# Altium schematic sheet STM32.SchDoc(STM32)
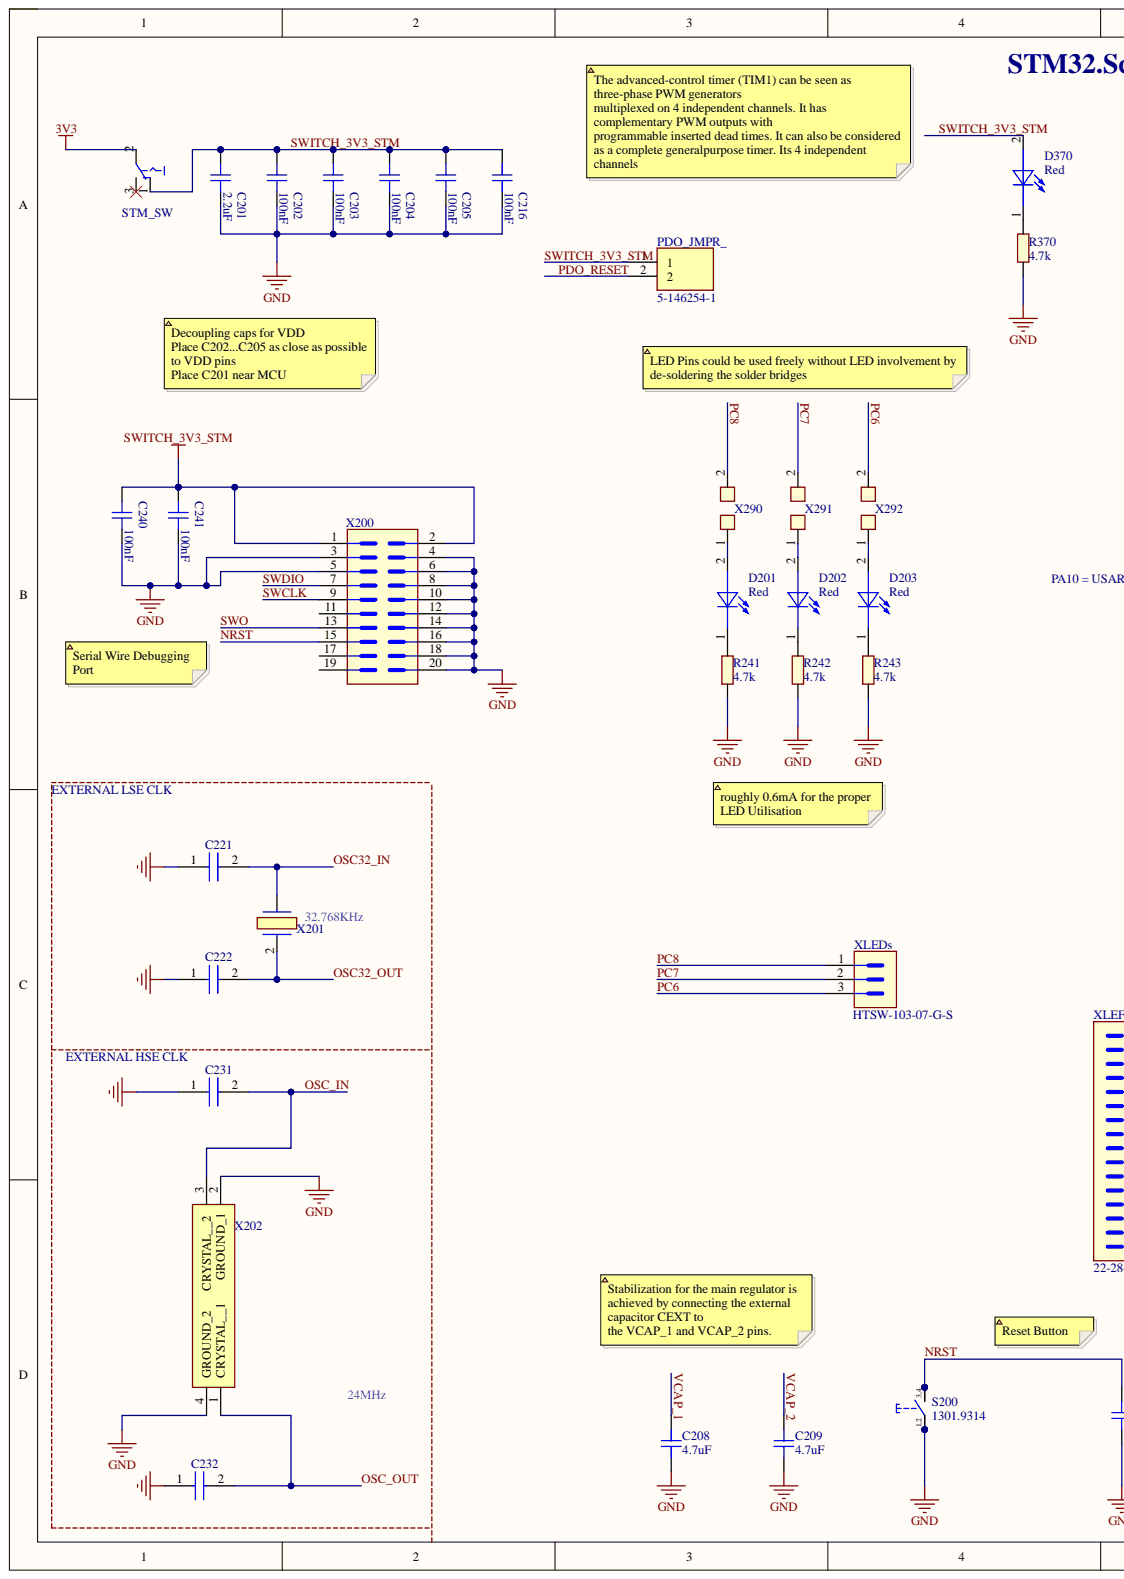
[diagram p0: STM32.SchDoc, left]
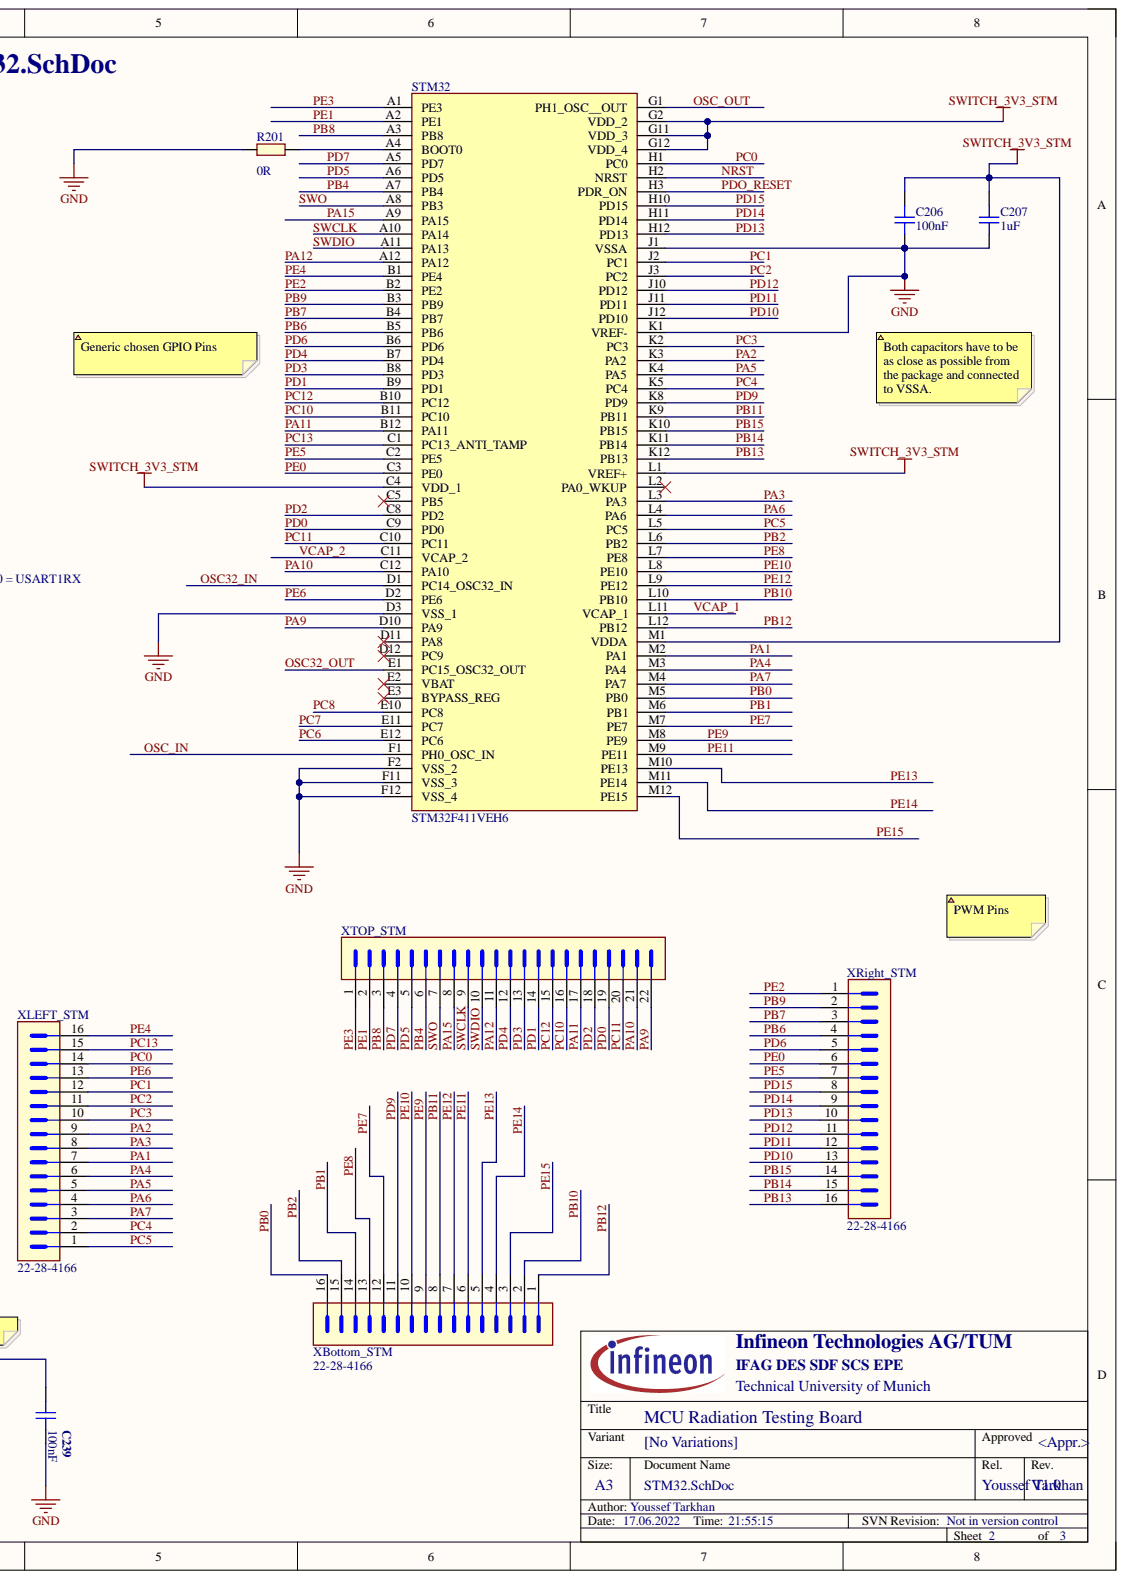
[diagram p1: STM32.SchDoc, right]

## Parts
C201  2.2uF  [CAPC1608X90N-3]
C202  100nF  [CAPC1608X90N-2]
C203  100nF  [CAPC1608X90N-2]
C204  100nF  [CAPC1608X90N-2]
C205  100nF  [CAPC1608X90N-2]
C206  100nF  [CAPC1608X90N-2]
C207  1uF  [CAPC1608X50N]
C208  4.7uF  [CAPC1608X95N]
C209  4.7uF  [CAPC1608X95N]
C216  100nF  [CAPC1608X90N-2]
C221  CC0402CRNPO9BN5R1  [CAPC1005X55N]  (value not drawn)
C222  CC0402CRNPO9BN5R1  [CAPC1005X55N]  (value not drawn)
C231  CC0603CRNPO9BN6R8  [CAPC1608X90N]  (value not drawn)
C232  CC0603CRNPO9BN6R8  [CAPC1608X90N]  (value not drawn)
C239  100nF  [CAPC1608X90N-2]
C240  100nF  [CAPC1608X90N-2]
C241  100nF  [CAPC1608X90N-2]
D201  Red  [LEDSC160X80X80-2N-1]
D202  Red  [LEDSC160X80X80-2N-1]
D203  Red  [LEDSC160X80X80-2N-1]
D370  Red  [LEDSC160X80X80-2N-1]
PDO_JMPR_  5-146254-1  [HDRV2W68P254_2X1_213X488X1045P]
R201  0R  [RESC1608X55N-1]
R241  4.7k  [RESC1609X55N]
R242  4.7k  [RESC1609X55N]
R243  4.7k  [RESC1609X55N]
R370  4.7k  [RESC1609X55N]
S200  1301.9314  [SW-SMD-1301.9314]
STM32  STM32F411VEH6  [BGA100C50P12X12_700X700X60]
STM_SW  CL-SB-12A-01T  [SW-SMD-CL-SB-12A-01T]  (value not drawn)
X200  827-22-020-10-003101  [CON-M-THT-827-22-020-10-003101]  (value not drawn)
X201  NX3215SA-32.768KHZ-EXS00A-MU00525  [NX3215SA32768KHZEXS00AMU00525]  (value not drawn)
X202  NX2016SA-24MHZ-EXS00A-CS10820  [NX2016SA24MHZEXS00ACS10820]  (value not drawn)
X290  Solder Jumper 2 Pins  [SOLDER_BRIDGE_0.7X1.299PAD]  (value not drawn)
X291  Solder Jumper 2 Pins  [SOLDER_BRIDGE_0.7X1.299PAD]  (value not drawn)
X292  Solder Jumper 2 Pins  [SOLDER_BRIDGE_0.7X1.299PAD]  (value not drawn)
XBottom_STM  22-28-4166  [HDRV16W66P254_1X16_4064X249X838B]
XLEDs  HTSW-103-07-G-S  [CON-THT-2.54-3-1-8.38]
XLEFT_STM  22-28-4166  [HDRV16W66P254_1X16_4064X249X838B]
XRight_STM  22-28-4166  [HDRV16W66P254_1X16_4064X249X838B]
XTOP_STM  TSW-122-07-L-S  [CON-M-THT-TSW-122-07-L-S]  (value not drawn)

## Nets
3V3: STM_SW.2
GND: C201.1 C202.1 C203.1 C204.1 C205.1 C206.2 C207.1 C208.1 C209.1 C216.1 C221.1 C222.1 C231.1 C232.1 C239.1 C240.1 C241.1 R201.1 R241.1 R242.1 R243.1 R370.1 S200.1 S200.2 STM32.D3(VSS_1) STM32.F2(VSS_2) STM32.F11(VSS_3) STM32.F12(VSS_4) STM32.J1(VSSA) STM32.K1(VREF-) X200.3 X200.4 X200.5 X200.6 X200.8 X200.10 X200.12 X200.14 X200.16 X200.18 X200.20 X202.2(GROUND_1) X202.4(GROUND_2)
NRST: C239.2 S200.3 S200.4 STM32.H2(NRST) X200.15
OSC32_IN: C221.2 STM32.D1(PC14_OSC32_IN) X201.
OSC32_OUT: C222.2 STM32.E1(PC15_OSC32_OUT) X201.2
OSC_IN: C231.2 STM32.F1(PH0_OSC_IN) X202.3(CRYSTAL__2)
OSC_OUT: C232.2 STM32.G1(PH1_OSC__OUT) X202.1(CRYSTAL__1)
PA1: STM32.M2(PA1) XLEFT_STM.7
PA2: STM32.K3(PA2) XLEFT_STM.9
PA3: STM32.L3(PA3) XLEFT_STM.8
PA4: STM32.M3(PA4) XLEFT_STM.6
PA5: STM32.K4(PA5) XLEFT_STM.5
PA6: STM32.L4(PA6) XLEFT_STM.4
PA7: STM32.M4(PA7) XLEFT_STM.3
PA9: STM32.D10(PA9) XTOP_STM.22
PA10: STM32.C12(PA10) XTOP_STM.21
PA11: STM32.B12(PA11) XTOP_STM.17
PA12: STM32.A12(PA12) XTOP_STM.11
PA15: STM32.A9(PA15) XTOP_STM.8
PB0: STM32.M5(PB0) XBottom_STM.16
PB1: STM32.M6(PB1) XBottom_STM.14
PB2: STM32.L6(PB2) XBottom_STM.15
PB4: STM32.A7(PB4) XTOP_STM.6
PB6: STM32.B5(PB6) XRight_STM.4
PB7: STM32.B4(PB7) XRight_STM.3
PB8: STM32.A3(PB8) XTOP_STM.3
PB9: STM32.B3(PB9) XRight_STM.2
PB10: STM32.L10(PB10) XBottom_STM.2
PB11: STM32.K9(PB11) XBottom_STM.8
PB12: STM32.L12(PB12) XBottom_STM.1
PB13: STM32.K12(PB13) XRight_STM.16
PB14: STM32.K11(PB14) XRight_STM.15
PB15: STM32.K10(PB15) XRight_STM.14
PC0: STM32.H1(PC0) XLEFT_STM.14
PC1: STM32.J2(PC1) XLEFT_STM.12
PC2: STM32.J3(PC2) XLEFT_STM.11
PC3: STM32.K2(PC3) XLEFT_STM.10
PC4: STM32.K5(PC4) XLEFT_STM.2
PC5: STM32.L5(PC5) XLEFT_STM.1
PC6: STM32.E12(PC6) X292.2 XLEDs.3
PC7: STM32.E11(PC7) X291.2 XLEDs.2
PC8: STM32.E10(PC8) X290.2 XLEDs.1
PC10: STM32.B11(PC10) XTOP_STM.16
PC11: STM32.C10(PC11) XTOP_STM.20
PC12: STM32.B10(PC12) XTOP_STM.15
PC13: STM32.C1(PC13_ANTI_TAMP) XLEFT_STM.15
PD0: STM32.C9(PD0) XTOP_STM.19
PD1: STM32.B9(PD1) XTOP_STM.14
PD2: STM32.C8(PD2) XTOP_STM.18
PD3: STM32.B8(PD3) XTOP_STM.13
PD4: STM32.B7(PD4) XTOP_STM.12
PD5: STM32.A6(PD5) XTOP_STM.5
PD6: STM32.B6(PD6) XRight_STM.5
PD7: STM32.A5(PD7) XTOP_STM.4
PD9: STM32.K8(PD9) XBottom_STM.11
PD10: STM32.J12(PD10) XRight_STM.13
PD11: STM32.J11(PD11) XRight_STM.12
PD12: STM32.J10(PD12) XRight_STM.11
PD13: STM32.H12(PD13) XRight_STM.10
PD14: STM32.H11(PD14) XRight_STM.9
PD15: STM32.H10(PD15) XRight_STM.8
PDO_RESET: PDO_JMPR_.2 STM32.H3(PDR_ON)
PE0: STM32.C3(PE0) XRight_STM.6
PE1: STM32.A2(PE1) XTOP_STM.2
PE2: STM32.B2(PE2) XRight_STM.1
PE3: STM32.A1(PE3) XTOP_STM.1
PE4: STM32.B1(PE4) XLEFT_STM.16
PE5: STM32.C2(PE5) XRight_STM.7
PE6: STM32.D2(PE6) XLEFT_STM.13
PE7: STM32.M7(PE7) XBottom_STM.12
PE8: STM32.L7(PE8) XBottom_STM.13
PE9: STM32.M8(PE9) XBottom_STM.9
PE10: STM32.L8(PE10) XBottom_STM.10
PE11: STM32.M9(PE11) XBottom_STM.6
PE12: STM32.L9(PE12) XBottom_STM.7
PE13: STM32.M10(PE13) XBottom_STM.5
PE14: STM32.M11(PE14) XBottom_STM.4
PE15: STM32.M12(PE15) XBottom_STM.3
SWCLK: STM32.A10(PA14) X200.9 XTOP_STM.9
SWDIO: STM32.A11(PA13) X200.7 XTOP_STM.10
SWITCH_3V3_STM: C201.2 C202.2 C203.2 C204.2 C205.2 C206.1 C207.2 C216.2 C240.2 C241.2 D370.2 PDO_JMPR_.1 STM32.C4(VDD_1) STM32.G2(VDD_2) STM32.G11(VDD_3) STM32.G12(VDD_4) STM32.L1(VREF+) STM32.M1(VDDA) STM_SW.1 X200.1 X200.2
SWO: STM32.A8(PB3) X200.13 XTOP_STM.7
VCAP_1: C208.2 STM32.L11(VCAP_1)
VCAP_2: C209.2 STM32.C11(VCAP_2)
NetD201_1: D201.1 R241.2
NetD201_2: D201.2 X290.1
NetD202_1: D202.1 R242.2
NetD202_2: D202.2 X291.1
NetD203_1: D203.1 R243.2
NetD203_2: D203.2 X292.1
NetD370_1: D370.1 R370.2
NetR201_2: R201.2 STM32.A4(BOOT0)
NetSTM32_E2: STM32.E2(VBAT)
NetSTM32_E3: STM32.E3(BYPASS_REG)
NetSTM32_L2: STM32.L2(PA0_WKUP)
NetSTM_SW_3: STM_SW.3
NetX200_11: X200.11
NetX200_17: X200.17
NetX200_19: X200.19

Not connected: STM32 C5(PB5) D11(PA8) D12(PC9)

## Library data of the parts
C201 = 310650: CAP / CERA / 2.2uF / 25V / 10% / X5R (EIA) / -55°C to 85°C / 0603(1608) / SMD / -; Manufacturer: MuRata; Manufacturer Order Number: GRM188R61E225KA12D; Alternate View: Yes; Automotive Qualified: No; Combined Parameters: TC:X5R (EIA);Tol.:-10%/+10%;Vdc:25V; ComponentStatus: Released; Database Table Name: TPartsAll; Legacy DeviceID: CAP01010924; Package Imperial: 0603; Package Metric: 1608; PLMStatus: Active; QuickPick: 2.2uF / 25V / 10% / X5R (EIA) / 0603 (1608M); Style: Single; Symbol Type: Capacitor; Single; Standard; 2 Pins; Type: Standard; Version Number: V01; Article Number: 310650; Component Kind: Standard; ComponentLink1Description: Vendor WebSite; ComponentLink1URL: http://www.murata.com/; ComponentLink2Description: Datasheet; ComponentLink2URL: http://webnetprod.muc.infineon.com/PCBPortal_Prod/WorkFlows/AltiumComponent/StandardDocumentation?Versionid=310651; Legacy PartNumber: CAP01010924; Library Name: PCBLibraryData.SVNDbLib; Multiple Parts: No; Package Description: Capacitor,non-polarized,Chip;1.60mm L X 0.80mm W X 0.90mm H; PackageReference: 0603 (1608M); Part Type: Capacitor; ROHS Compliant: Y; Second Source allowed: Yes; Supplier 1: Farnell; Supplier 2: DigiKey; Supplier 3: Mouser; Supplier Part Number 1: 1845734RL; Supplier Part Number 2: 490-10731-2-ND; Supplier Part Number 3: 81-GRM188R61E225KA2D; Technology: Ceramic; Temperature max: 85; Temperature min: -55; Temperature Range: Military; Tolerance Neg: 10%; Tolerance Pos: 10%; Value: 2.2uF; Voltage DC (max): 25V
C202-C206 C216 C239-C241 = 479045: CAP / CERA / 100nF / 50V / 10% / X7R (EIA) / -55°C to 125°C / 0603 / SMD / -; Manufacturer: AVX; Manufacturer Order Number: 06035C104KAT2A; Alternate View: Yes; Automotive Qualified: No; Combined Parameters: TC:X7R (EIA);Tol.:-10%/+10%;Vdc:50V; ComponentStatus: Released; Database Table Name: TPartsAll; PLMStatus: Active; QuickPick: 100nF / 50V / 10% / X7R (EIA) / 0603; Style: Single; Symbol Type: Capacitor; Single; Standard; 2 Pins; Type: Standard; Version Number: V01; Application: Standard; Article Number: 479045; Component Kind: Standard; ComponentLink1Description: Vendor WebSite; ComponentLink1URL: http://www.avx.com; ComponentLink2Description: Datasheet; ComponentLink2URL: http://webnetprod.muc.infineon.com/PCBPortal_Prod/WorkFlows/AltiumComponent/StandardDocumentation?Versionid=479046; Library Name: PCBLibraryData.SVNDbLib; Multiple Parts: No; Package Description: Capacitor,non-polarized,Chip;1.60mm L X 0.81mm W X 0.90mm H; PackageReference: 0603; Part Type: Capacitor; ROHS Compliant: Y; Second Source allowed: Yes; Supplier 1: Farnell; Supplier 2: DigiKey; Supplier 3: Mouser; Supplier Part Number 1: 2280883; Supplier Part Number 2: 478-5052-2-ND; Supplier Part Number 3: 581-06035C104KAT2A; Technology: Ceramic; Temperature max: 125; Temperature min: -55; Temperature Range: Military; Tolerance Neg: 10%; Tolerance Pos: 10%; Value: 100nF; Voltage DC (max): 50V
C207 = 267094: CAP / CERA / 1uF / 6.3V / 10% / X5R (EIA) / -55°C to 85°C / 0603(1608) / SMD / -; Manufacturer: MuRata; Manufacturer Order Number: GRM185R60J105KE26; Alternate View: Yes; Automotive Qualified: No; Combined Parameters: TC:X5R (EIA);Tol.:-10%/+10%;Vdc:6.3V; ComponentStatus: Released; Database Table Name: TPartsAll; Legacy DeviceID: CAP01002425; Package Imperial: 0603; Package Metric: 1608; PLMStatus: Not Recommended For New Designs; QuickPick: 1uF / 6.3V / 10% / X5R (EIA) / 0603 (1608); Style: Single; Symbol Type: Capacitor; Single; Standard; 2 Pins; Type: Standard; Version Number: V01; Application: Standard; Article Number: 267094; Component Kind: Standard; ComponentLink1Description: Vendor WebSite; ComponentLink1URL: http://www.murata.com/; ComponentLink2Description: Datasheet; ComponentLink2URL: http://webnetprod.muc.infineon.com/PCBPortal_Prod/WorkFlows/AltiumComponent/StandardDocumentation?Versionid=267095; Legacy PartNumber: CAP01002425; Library Name: PCBLibraryData.SVNDbLib; Multiple Parts: No; Package Description: Capacitor,non-polarized,Chip;1.60mm L X 0.80mm W X 0.50mm H; PackageReference: 0603 (1608); Part Type: Capacitor; ROHS Compliant: Y; Second Source allowed: Yes; Supplier 1: Farnell; Supplier 2: DigiKey; Supplier 3: Mouser; Technology: Ceramic; Temperature max: 85; Temperature min: -55; Temperature Range: Military; Tolerance Neg: 10%; Tolerance Pos: 10%; Value: 1uF; Voltage DC (max): 6.3V
C208 C209 = 308695: CAP / CERA / 4.7uF / 6.3V / 10% / X7R (EIA) / -55°C to 125°C / 0603(1608) / SMD / -; Manufacturer: Samsung; Manufacturer Order Number: CL10B475KQ8NQNC; Alternate View: Yes; Automotive Qualified: No; Combined Parameters: TC:X7R (EIA);Tol.:-10%/+10%;Vdc:6.3V; ComponentStatus: Released; Database Table Name: TPartsAll; Legacy DeviceID: CAP01010671; Package Imperial: 0603; Package Metric: 1608; PLMStatus: Active; QuickPick: 4.7uF / 6.3V / 10% / X7R (EIA) / 0603 (1608); Style: Single; Symbol Type: Capacitor; Single; Standard; 2 Pins; Type: Standard; Version Number: V01; Article Number: 308695; Component Kind: Standard; ComponentLink1Description: Vendor WebSite; ComponentLink1URL: http://www.samsungsem.co.kr/; ComponentLink2Description: Datasheet; ComponentLink2URL: http://webnetprod.muc.infineon.com/PCBPortal_Prod/WorkFlows/AltiumComponent/StandardDocumentation?Versionid=308696; Legacy PartNumber: CAP01010671; Library Name: PCBLibraryData.SVNDbLib; Multiple Parts: No; Package Description: Capacitor,non-polarized,Chip;1.60mm L X 0.80mm W X 0.95mm H; PackageReference: 0603 (1608); Part Type: Capacitor; ROHS Compliant: Y; Second Source allowed: Yes; Supplier 1: Farnell; Supplier 2: DigiKey; Supplier 3: Mouser; Supplier Part Number 2: 1276-2087-2-ND; Technology: Ceramic; Temperature max: 125; Temperature min: -55; Temperature Range: Military; Tolerance Neg: 10%; Tolerance Pos: 10%; Value: 4.7uF; Voltage DC (max): 6.3V
C221 C222 = CC0402CRNPO9BN5R1: Capacitor; Manufacturer_Name: KEMET; Component Kind: Standard; Datasheet Link: https://www.yageo.com/upload/media/product/productsearch/datasheet/mlcc/UPY-GP_NP0_16V-to-50V_18.pdf; Height: 0.55mm; Manufacturer_Part_Number: CC0402CRNPO9BN5R1
C231 C232 = CC0603CRNPO9BN6R8: Capacitor  6.8pF; Manufacturer_Name: KEMET; Component Kind: Standard; Height: 0.9mm; Manufacturer_Part_Number: CC0603CRNPO9BN6R8
D201-D203 D370 = 625459: WL-SMCW SMT Mono-color Chip LED Waterclear, Red,630nm; Manufacturer: Wurth Elektronik; Manufacturer Order Number: 150060RS75000; Automotive Qualified: No; Combined Parameters: Vf:2.4V;If:30mA;Ur:5V;Ir:10uA;Pmax:72mW; ComponentStatus: Released; Database Table Name: TPartsAll; PLMStatus: Active; QuickPick: LED / 1608 (0603); Second Source allowed: Yes; Style: Single; Symbol Type: Standard LED Symbol, 1 Cathode, 2 Anode; Version Number: V01; Voltage DC (max): na; Application: Standard; Article Number: 625459; Component Kind: Standard; ComponentLink1Description: Vendor WebSite; ComponentLink1URL: http://www.we-online.com; ComponentLink2Description: Datasheet; ComponentLink2URL: http://webnetprod.muc.infineon.com/PCBPortal_Prod/WorkFlows/AltiumComponent/StandardDocumentation?Versionid=625460; Library Name: PCBLibraryData.SVNDbLib; Package Description: LED,Side Concave; 2 pin,1.60mm W X 0.80mm L X 0.80mm H; PackageReference: 1608 (0603); Part Type: Diode; ROHS Compliant: Y; Supplier 1: Farnell; Supplier 2: DigiKey; Supplier 3: Mouser; Supplier Part Number 1: 2322071; Supplier Part Number 2: 732-4978-1-ND; Supplier Part Number 3: 710-150060RS75000; Temperature max: 85; Temperature min: -40; Temperature Range: Industrial; Type: LED; Value: Red
PDO_JMPR_ = 5-146254-1: Connector; Manufacturer_Name: TE Connectivity; Component Kind: Standard; Datasheet Link: https://www.te.com/commerce/DocumentDelivery/DDEController?Action=srchrtrv&DocNm=146254&DocType=Customer+Drawing&DocLang=English; Height: 10.45mm; Manufacturer_Part_Number: 5-146254-1
R201 = 328011: RES / STD / 0R / 100mW / 0R / 0ppm/K / -55°C to 155°C / 0603(1608) / SMD / -; Manufacturer: Yageo; Manufacturer Order Number: RC0603JR-070RL; Alternate View: Yes; Automotive Qualified: No; Combined Parameters: TCR:0ppm/K;Tol.:-0R/+0R;Vdc:75V;Idc:1A;P:100mW; ComponentStatus: Released; Database Table Name: TPartsAll; Legacy DeviceID: RES00000126; Package Imperial: 0603; Package Metric: 1608; PLMStatus: Active; QuickPick: 0R / 0R / 0.1 / 0603 (1608); Style: Single; Symbol Type: Resistor; Single; Standard; 2 Pins; Version Number: V01; Voltage DC (max): 75V; Article Number: 328011; Component Kind: Standard; ComponentLink1Description: Vendor WebSite; ComponentLink1URL: http://www.yageo.com/; ComponentLink2Description: Datasheet; ComponentLink2URL: http://webnetprod.muc.infineon.com/PCBPortal_Prod/WorkFlows/AltiumComponent/StandardDocumentation?Versionid=328012; Legacy PartNumber: RES00000126; Library Name: PCBLibraryData.SVNDbLib; Multiple Parts: No; PackageReference: 0603 (1608); Part Type: Resistor; ROHS Compliant: Y; Second Source allowed: Yes; Supplier 1: Farnell; Supplier 2: DigiKey; Supplier 3: Mouser; Supplier Part Number 1: 9233130; Supplier Part Number 2: 311-0.0GRTR-ND; Supplier Part Number 3: 603-RC0603JR-070RL; Technology: Thick Film; Temperature max: 155; Temperature min: -55; Temperature Range: Military; Tolerance Neg: 0R; Tolerance Pos: 0R; Type: Standard; Value: 0R
R241-R243 R370 = 699381: RES / STD / 4.7k / 100mW / 1% / 100ppm/K / -55°C to 155°C / 1.60mm L X 0.85mm W X 0.55mm H / - / -; Manufacturer: Panasonic; Manufacturer Order Number: ERJ3EKF4701V; Multiple Parts: No; Alternate View: Yes; Automotive Qualified: AEC Q200; Combined Parameters: TCR:100ppm/K;Tol.:-1%/+1%;Vdc:-;Idc:-;P:100mW; ComponentStatus: Released; Database Table Name: TPartsAll; PLMStatus: Active; QuickPick: 4.7k / 1% / 0.1 / ERJ3EKF4701V; Style: Single; Symbol Type: Resistor; Single; Standard; 2 Pins; Version Number: V00; Application: Standard; Article Number: 699381; Component Kind: Standard; ComponentLink1Description: Vendor WebSite; ComponentLink1URL: http://panasonic.net/sanyo/index.html; ComponentLink2Description: Datasheet; ComponentLink2URL: http://webnetprod.muc.infineon.com/PCBPortal_Prod/WorkFlows/AltiumComponent/StandardDocumentation?Versionid=699382; Library Name: PCBLibraryData.SVNDbLib; Package Description: Resistor,Chip;1.60mm L X 0.85mm W X 0.55mm H; PackageReference: ERJ3EKF4701V; Part Type: Resistor; ROHS Compliant: Y; Second Source allowed: Yes; Supplier 1: Farnell; Supplier 2: DigiKey; Supplier 3: Mouser; Supplier Part Number 1: 2303181; Supplier Part Number 2: P4.70KHCT-ND; Supplier Part Number 3: 667-ERJ-3EKF4701V; Technology: Thick Film; Temperature max: 155; Temperature min: -55; Temperature Range: Military; Tolerance Neg: 1%; Tolerance Pos: 1%; Type: Standard; Value: 4.7k
S200 = 380147: Pushbutton Tactile Switch, Single Pole Single Throw, 4 Pins; Legacy PartNumber: CON01500305; Manufacturer: Schurter; ComponentStatus: Released; Database Table Name: TPartsAll; Legacy DeviceID: SCH_1301.9314; PLMStatus: Active; Second Source allowed: Yes; Symbol type: Tactile Switch, Spring Return, Single Pole Single Throw - Normally Open, 4 Pins; Version Number: V01; Voltage DC (max): na; Article Number: 380147; Component Kind: Standard; ComponentLink1Description: Vendor WebSite; ComponentLink1URL: http://www.schurter.com.sg/; ComponentLink2Description: Datasheet; ComponentLink2URL: http://webnetprod.muc.infineon.com/PCBPortal_Prod/WorkFlows/AltiumComponent/StandardDocumentation?Versionid=380148; Library Name: PCBLibraryData.SVNDbLib; Manufacturer Order Number: 1301.9314; Package Description: SMD Switch, 6.00mm L X 6.00mm W X 4.3mm H body; Part Type: Electro Mechanic; ROHS Compliant: Y; Supplier 1: Farnell; Supplier 2: DigiKey; Supplier 3: Mouser; Supplier Part Number 1: 1217763; Supplier Part Number 2: 1301.9314-ND; Supplier Part Number 3: 693-1301.9314; Temperature max: 70; Temperature min: -20; Temperature Range: Commercial; Value: 1301.9314
STM32 = STM32F411VEH6: Integrated Circuit; Manufacturer_Name: STMicroelectronics; Component Kind: Standard; Datasheet Link: https://www.st.com/resource/en/datasheet/stm32f411ve.pdf; Height: 0.6mm; Manufacturer_Part_Number: STM32F411VEH6
STM_SW = 650099: Slid Switch, 12V, 200mA; Manufacturer: NIDEC COPAL ELECTRONICS, Inc.; Automotive Qualified: No; ComponentStatus: Released; Database Table Name: TPartsAll; PLMStatus: Active; Second Source allowed: Yes; Symbol Type: Switch, 2 Change Over 1 3, 3 Pins; Version Number: V00; Voltage DC (max): na; Application: Power; Article Number: 650099; Component Kind: Standard; ComponentLink1Description: Vendor WebSite; ComponentLink1URL: www.copal-electronics.com/; ComponentLink2Description: Datasheet; ComponentLink2URL: http://webnetprod.muc.infineon.com/PCBPortal_Prod/WorkFlows/AltiumComponent/StandardDocumentation?Versionid=650100; Library Name: PCBLibraryData.SVNDbLib; Manufacturer Order Number: CL-SB-12A-01T; Package Description: Switch, SMD, 3 Pins, 3.50 mm W X 8.50 mm L X 6.10 mm H body; Part Type: Electro Mechanic; ROHS Compliant: Y; Supplier 1: Farnell; Supplier 2: DigiKey; Supplier 3: Mouser; Supplier Part Number 1: 2854744; Supplier Part Number 2: 563-1314-1-ND; Supplier Part Number 3: 229-CL-SB-12A-01T; Temperature max: 85; Temperature min: -40; Temperature Range: Industrial; Value: CL-SB-12A-01T
X200 = 602795: Spring Piston Connector Through Hole, 20 positions; Manufacturer: Mill-Max; Automotive Qualified: No; Combined Parameters: Board-to-Cable Header (male);10x2;Mounting:THT;Orient:0° (vertical);Shielded:No; ComponentStatus: Released; Database Table Name: TPartsAll; Legacy DeviceID: MIL_827-22-020-10-003101; PLMStatus: Active; QuickPick: 0° (vertical) / Board-to-Cable; Second Source allowed: Yes; Style: Strip; Symbol Type: Header 10x2; Version Number: V01; Voltage DC (max): na; Application: Standard Interface; Article Number: 602795; Component Kind: Standard; ComponentLink1Description: Vendor WebSite; ComponentLink1URL: http://www.mill-max.com/; ComponentLink2Description: Datasheet; ComponentLink2URL: http://webnetprod.muc.infineon.com/PCBPortal_Prod/WorkFlows/AltiumComponent/StandardDocumentation?Versionid=602796; Library Name: PCBLibraryData.SVNDbLib; Manufacturer Order Number: 827-22-020-10-003101; Package Description: Header, THT, 2.54 mm Pitch; 20 pin, 2 rows, 10 pins per row, 5.08 mm L X 25.4 mm W X 9.19mm H body; PackageReference: THT; Part Type: Connector; ROHS Compliant: Y; Supplier 1: Farnell; Supplier 2: DigiKey; Supplier 3: Mouser; Supplier Part Number 2: ED1406-ND; Supplier Part Number 3: 575-8272202010003101; Temperature max: 0; Temperature min: 0; Temperature Range: Military; Type: Board-to-Cable; Value: 827-22-020-10-003101
X201 = NX3215SA-32.768KHZ-EXS00A-MU00525: Crystal or Oscillator; Manufacturer_Name: NDK; Component Kind: Standard; Datasheet Link: https://www.ndk.com/en/products/search/crystal/1188854_1494.html; Height: 0.9mm; Manufacturer_Part_Number: NX3215SA-32.768KHZ-EXS00A-MU00525
X202 = NX2016SA-24MHZ-EXS00A-CS10820: Crystal or Oscillator; Manufacturer_Name: NDK; Component Kind: Standard; Datasheet Link: https://www.ndk.com/images/products/catalog/c_NX2016SA-2_e.pdf; Height: 0.5mm; Manufacturer_Part_Number: NX2016SA-24MHZ-EXS00A-CS10820
X290-X292 = 433601: Legacy PartNumber: CON01500959; Manufacturer: Infineon Technologies; Alternate View: Yes; Automotive Qualified: No; Combined Parameters: - None (onboard);2x1;Mounting:SMT;Orient:None (onboard);Shielded:No; ComponentStatus: Released; Database Table Name: TPartsAll; Legacy DeviceID: IFX_Solder Jumper 2 Pins; PLMStatus: Active; QuickPick: None (onboard); Style: Not Applicable; Version Number: V01; Voltage DC (max): na; Article Number: 433601; Component Kind: Standard; ComponentLink1Description: Vendor WebSite; ComponentLink1URL: https://www.infineon.com/; ComponentLink2Description: Datasheet; Description: Solder Jumper 2 Pins; Library Name: PCBLibraryData.SVNDbLib; Manufacturer Order Number: Solder Jumper 2 Pins; Multiple Parts: No; Package Description: Solder Bridge 2 Pins, 0.7X1.299mm Pad, 1.6mm L X 1.2mm W body; Part Type: Connector; ROHS Compliant: N; Second Source allowed: Yes; Supplier 1: Farnell; Supplier 2: DigiKey; Supplier 3: Mouser; Symbol Type: Solder Jumper 2 Pins; Temperature max: 0; Temperature min: 0; Temperature Range: Military; Value: Solder Jumper 2 Pins
XBottom_STM XLEFT_STM XRight_STM = 377324: 2.54mm Pitch KK header, Breakaway, Vertical, 16 Circuits, 0.76um Gold Selective Plating, Mating Pin Length 6.09mm; Legacy PartNumber: CON01500040; Manufacturer: Molex; Alternate View: No; Combined Parameters: Board-to-Board Header (male);16x1;Mounting:THT;Orient:0° (vertical);Shielded:No; ComponentStatus: Released; Database Table Name: TPartsAll; Legacy DeviceID: MOL_22-28-4166; PLMStatus: Active; QuickPick: 0° (vertical) / Board-to-Board; Second Source allowed: Yes; Style: Strip; Symbol Type: Header 16 pins; Version Number: V01; Voltage DC (max): na; Article Number: 377324; Automotive Qualified: No; Component Kind: Standard; ComponentLink1Description: Vendor WebSite; ComponentLink1URL: http://www.molex.com; ComponentLink2Description: Datasheet; ComponentLink2URL: http://webnetprod.muc.infineon.com/PCBPortal_Prod/WorkFlows/AltiumComponent/StandardDocumentation?Versionid=377325; Library Name: PCBLibraryData.SVNDbLib; Manufacturer Order Number: 22-28-4166; Multiple Parts: No; Package Description: 2.54mm Pitch KK header, Breakaway, Vertical, 16 Circuits, 0.76um Gold Selective Plating, Mating Pin Length 6.09mm; Part Type: Connector; ROHS Compliant: Y; Supplier 1: Farnell; Supplier 2: DigiKey; Supplier 3: Mouser; Supplier Part Number 2: 22-28-4166-ND; Supplier Part Number 3: 538-22-28-4166; Temperature max: 105; Temperature min: -40; Temperature Range: Industrial; Type: Board-to-Board; Value: 22-28-4166
XLEDs = 375892: Through hole .025 SQ Post Header, 2.54mm pitch, 3 pin, vertical, single row; Legacy PartNumber: CON00000054; Manufacturer: Samtec; Automotive Qualified: No; Combined Parameters: Board-to-Board Header (male);3x1;Mounting:THT;Orient:0° (vertical);Shielded:No; ComponentStatus: Released; Database Table Name: TPartsAll; Legacy DeviceID: SAM_HTSW-103-07-G-S; PLMStatus: Active; QuickPick: 0° (vertical) / Board-to-Board; Second Source allowed: Yes; Style: Strip; Version Number: V01; Voltage DC (max): na; Article Number: 375892; Component Kind: Standard; ComponentLink1Description: Vendor WebSite; ComponentLink1URL: http://www.samtec.com/; ComponentLink2Description: Datasheet; ComponentLink2URL: http://webnetprod.muc.infineon.com/PCBPortal_Prod/WorkFlows/AltiumComponent/StandardDocumentation?Versionid=375893; Library Name: PCBLibraryData.SVNDbLib; Manufacturer Order Number: HTSW-103-07-G-S; Package Description: Header,vertical,2.54mm pitch;0.64mm lead width,3 pins,1 row,3 pins per row,7.62mm L X 2.48mm W X 8.38mm H body; Part Type: Connector; ROHS Compliant: Y; Supplier 1: Farnell; Supplier 2: DigiKey; Supplier 3: Mouser; Supplier Part Number 1: 1925743; Supplier Part Number 2: SAM8814-ND; Temperature max: 125; Temperature min: -55; Temperature Range: Military; Type: Board-to-Board; Value: HTSW-103-07-G-S
XTOP_STM = 385945: Through hole .025 SQ Post Header, 2.54mm pitch, 22 pin, vertical, single row; Legacy PartNumber: CON01500868; Manufacturer: Samtec; Automotive Qualified: No; Combined Parameters: Board-to-Board/Cable Header (male);22x1;Mounting:THT;Orient:0° (vertical);Shielded:No; ComponentStatus: Released; Database Table Name: TPartsAll; Legacy DeviceID: SAM_TSW-122-07-L-S; PLMStatus: Active; QuickPick: 0° (vertical) / Board-to-Board/Cable; Second Source allowed: Yes; Style: Strip; Symbol type: Header 22pins; Version Number: V01; Voltage DC (max): na; Article Number: 385945; Component Kind: Standard; ComponentLink1Description: Vendor WebSite; ComponentLink1URL: http://www.samtec.com/; ComponentLink2Description: Datasheet; ComponentLink2URL: http://webnetprod.muc.infineon.com/PCBPortal_Prod/WorkFlows/AltiumComponent/StandardDocumentation?Versionid=385946; Library Name: PCBLibraryData.SVNDbLib; Manufacturer Order Number: TSW-122-07-L-S; Package Description: Header, Vertical, 2.54mm pitch; 0.64mm lead width, 22 pins, Single row, 55.88mm L X 2.54mm W X 8.712mm H body; Part Type: Connector; ROHS Compliant: Y; Supplier 1: Farnell; Supplier 2: DigiKey; Supplier 3: Mouser; Supplier Part Number 1: 2035626; Supplier Part Number 2: SAM1031-22-ND; Temperature max: 125; Temperature min: -55; Temperature Range: Military; Type: Board-to-Board/Cable; Value: TSW-122-07-L-S
